# Altium schematic sheet Schema.SchDoc(Schema)
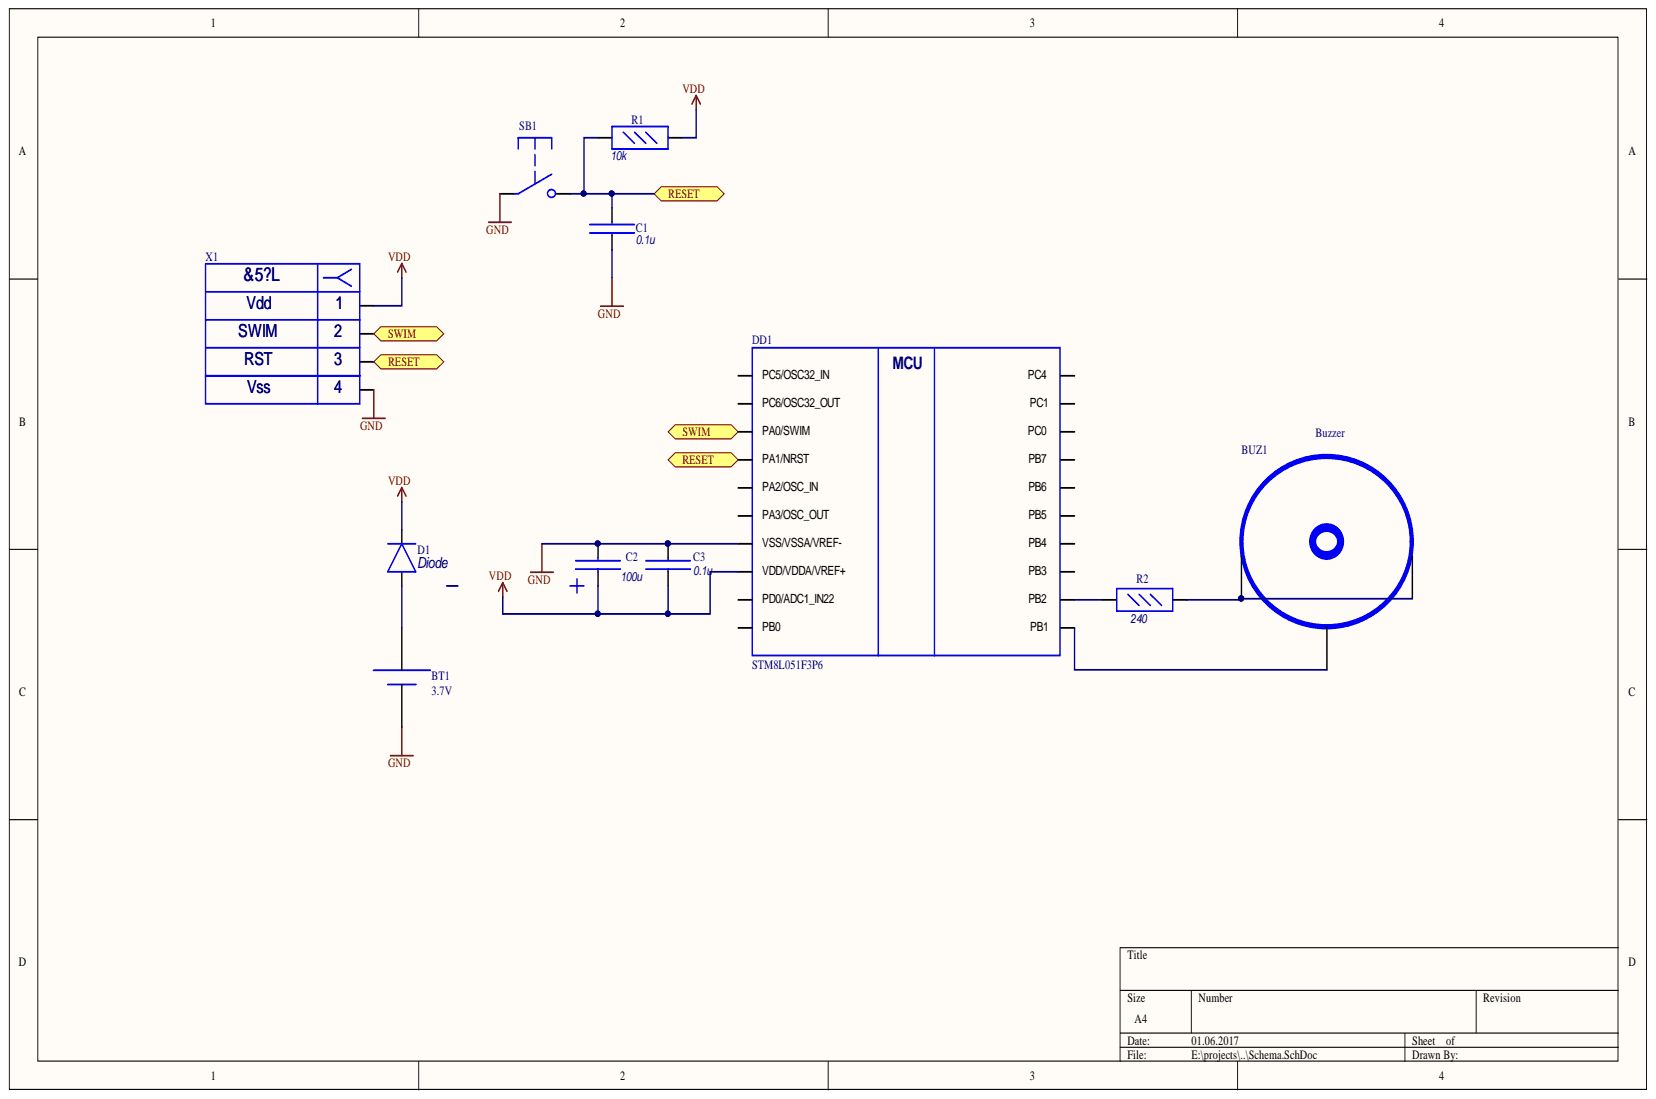
[diagram p0: Schema.SchDoc]

## Parts
BT1  3.7V  [Battery]
BUZ1  Buzzer  [Buzzer]
C1  Cap: 0.1u  [CAPACITOR SMD 1206]  (hidden comment: Capacitor)
C2  Cap: 100u  [BigCapacitor]  (hidden comment: Capacitor (Polarized))
C3  Cap: 0.1u  [CAPACITOR SMD 1206]  (hidden comment: Capacitor)
D1  Diode  [SODFL250X110-2N]
DD1  STM8L051F3P6  [TSOP65P640X120-20N]
R1  R: 10k  [Resistor SMD 1206]  (hidden comment: Resistor (0.05W))
R2  Resistor (0.05W)  [Resistor SMD 1206]  (value not drawn)
SB1  Button  [BUTTON SMD]  (value not drawn)
X1  SWIM_Connector  [SWIM Connector]  (value not drawn)

## Ports
RESET, SWIM

## Nets
GND: BT1.2 C1.1 C2.2 C3.2 DD1.7(VSS/VSSA/VREF-) SB1.1 X1.4
VDD: C2.1 C3.1 D1.2 DD1.8(VDD/VDDA/VREF+) R1.1 X1.1
RESET: C1.2 DD1.4(PA1/NRST) R1.2 SB1.2 X1.3 ; port RESET
SWIM: DD1.3(PA0/SWIM) X1.2 ; port SWIM
NetBT1_1: BT1.1 D1.1
NetBUZ1_1: BUZ1.1 BUZ1.2 R2.2
NetBUZ1_3: BUZ1.3 DD1.11(PB1)
NetDD1_12: DD1.12(PB2) R2.1
N00002:

Not connected: DD1 1(PC5/OSC32_IN) 2(PC6/OSC32_OUT) 5(PA2/OSC_IN) 6(PA3/OSC_OUT) 9(PD0/ADC1_IN22) 10(PB0) 13(PB3) 14(PB4) 15(PB5) 16(PB6) 17(PB7) 18(PC0) 19(PC1) 20(PC4)

## Library data of the parts
BT1 = Battery: Component Kind: Standard; Library Name: Components.SchLib
DD1 = STM8L051F3P6 (TSSOP-20): Component Kind: Standard; Library Name: Components.SchLib
R2 = Resistor (0.05W): R: 240; Component Kind: Standard; Library Name: Components.SchLib
BUZ1 = Buzzer: Component Kind: Standard; Library Name: Components.SchLib
C1 C3 = Capacitor: Component Kind: Standard; Library Name: Components.SchLib
C2 = Capacitor (Polarized): Component Kind: Standard; Library Name: Components.SchLib
D1 = Diode: Component Kind: Standard; Library Name: Components.SchLib
R1 = Resistor (0.05W): Component Kind: Standard; Library Name: Components.SchLib
SB1 = Button: Component Kind: Standard; Library Name: Components.SchLib
X1 = SWIM_Connector: Component Kind: Standard; Library Name: Components.SchLib
